# Altium schematic sheet UART_Bridge.SchDoc
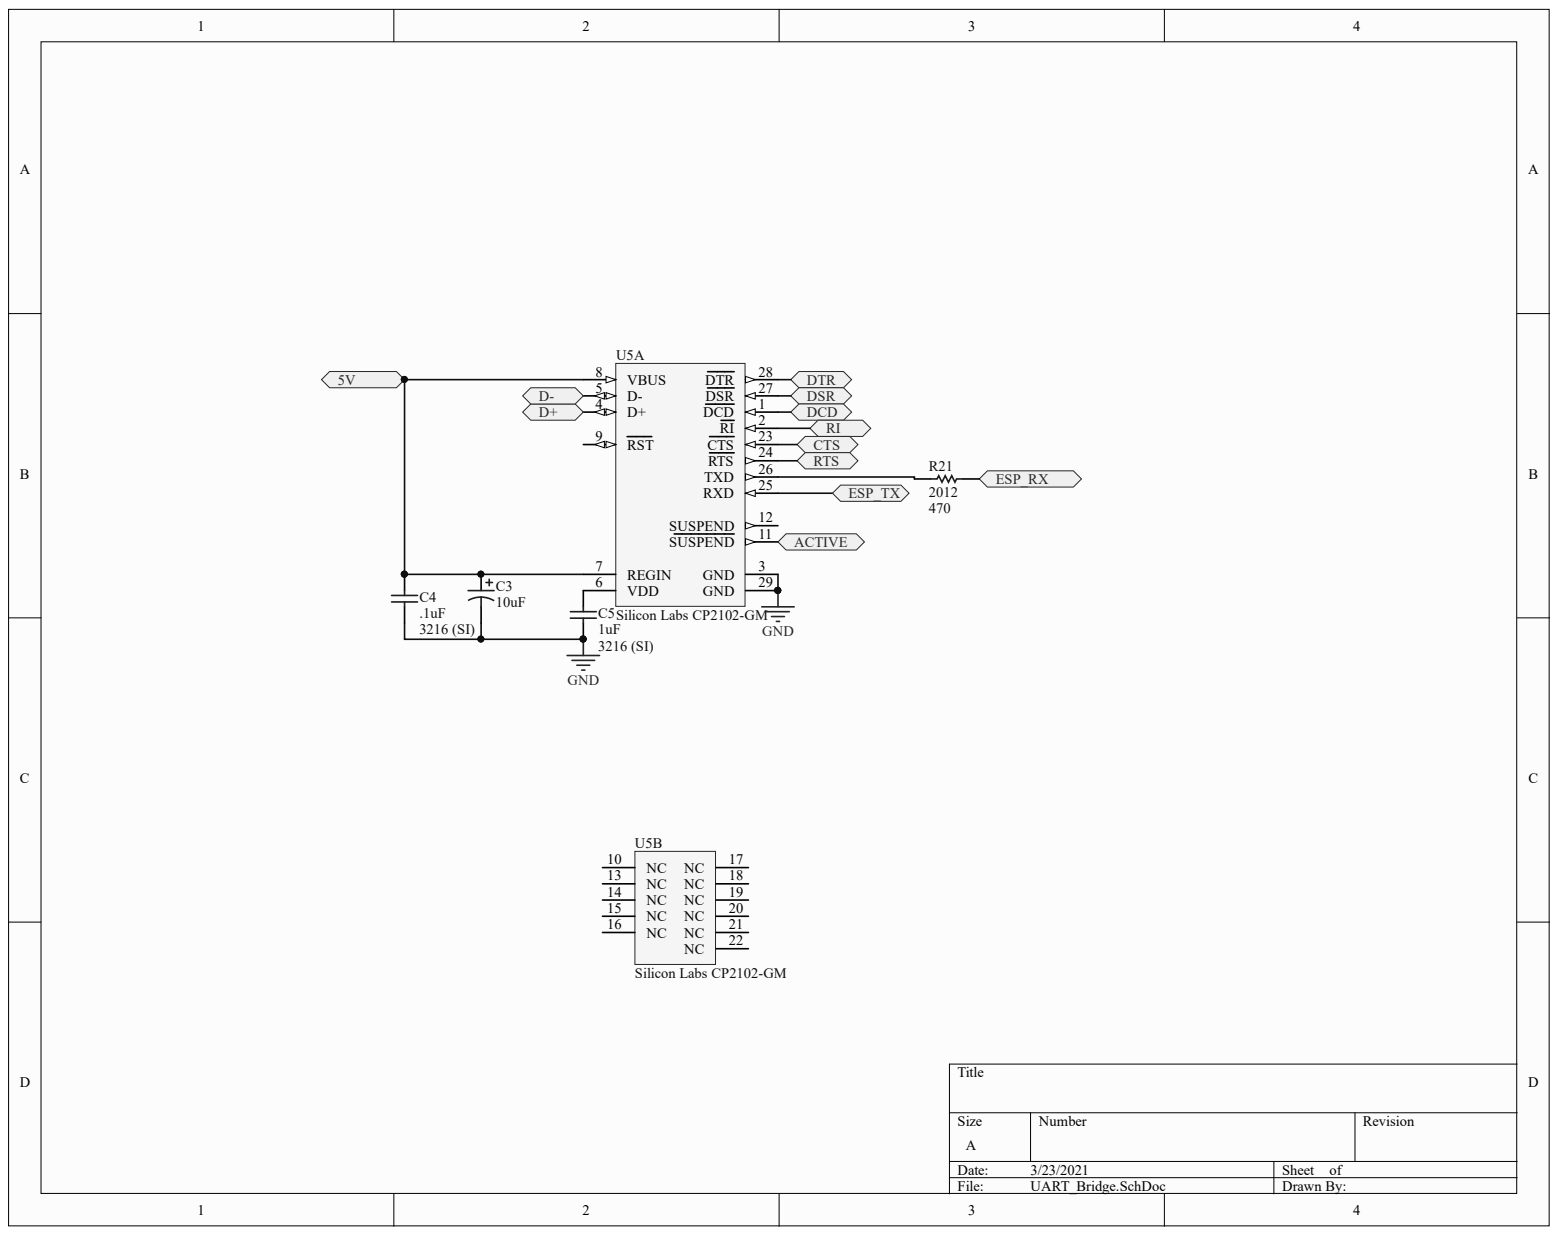
[diagram p0: UART_Bridge.SchDoc]

## Parts
C3  10uF  [PCB-imxzc0h3ulzopa7zwnih-1]
C4  .1uF  [CSMD1206GRID25PADB]
C5  1uF  [CSMD1206GRID25PADB]
R21  Case/Package (SI): 2012  [RESC2013X70X40ML20T20]  (hidden comment: Panasonic ERJ-6GEYJ822V)
U5  Silicon Labs CP2102-GM  Manufacturer Part Number: CP2102-GM  [PCB-r2q4wj0b96jlqp92htuq-1]  (parts A,B)

## Ports
5V, ACTIVE, CTS, D+, D-, DCD, DSR, DTR, ESP_RX, ESP_TX, RI, RTS

## Nets
GND: C3.2 C4.1 C5.1 U5.3(GND) U5.29(GND)
5V: C3.1 C4.2 U5.7(REGIN) U5.8(VBUS) ; port 5V
ACTIVE: U5.11(SUSPEND) ; port ACTIVE
CTS: U5.23(CTS) ; port CTS
D+: U5.4(D+) ; port D+
D-: U5.5(D-) ; port D-
DCD: U5.1(DCD) ; port DCD
DSR: U5.27(DSR) ; port DSR
DTR: U5.28(DTR) ; port DTR
ESP_RX: R21.1 ; port ESP_RX
ESP_TX: U5.25(RXD) ; port ESP_TX
RI: U5.2(RI) ; port RI
RTS: U5.24(RTS) ; port RTS
NetC5_2: C5.2 U5.6(VDD)
NetR21_2: R21.2 U5.26(TXD)

Not connected: U5 9(RST) 10(NC) 12(SUSPEND) 13(NC) 14(NC) 15(NC) 16(NC) 17(NC) 18(NC) 19(NC) 20(NC) 21(NC) 22(NC)

## Library data of the parts
C3 = CMP-6a29a3234e15b5ee-2: Capacitor Tantalum Solid 10uF 25V 10% (5.5 X 9mm) Radial 2.5mm 2.5 Ohm 125C Bulk; Manufacturer Part Number: TAP106K025SCS; Capacitance: 10.0 µF; Equivalent Series Resistance (ESR): 2.50 Ω; Lead-Free Status: Lead Free; Capacitance Tolerance: ±10%; Component Kind: Standard; Library Name: CommunityVault; Mounting Style: Through Hole; Number of Pins: 2; OctopartID: 6a29a3234e15b5ee; Octopart URL: http://octopart.com/tap106k025scs-avx-346; REACH SVHC Compliance: No SVHC; RoHS: Compliant; Size-Diameter: 5.59 mm; Size-Height: 8.89 mm
C4 C5 = CMP-150561-3: Cap Ceramic 1uF 25V X7R 20% SMD 1206 125C Plastic T/R; Lead-Free Status: R; Voltage Rating (DC): 25.0 V; Capacitance Tolerance: ±20%; Component Kind: Standard; Library Name: CommunityVault; Mounting Style: Surface Mount; OctopartID: f0ac39d8598d2f6b; Octopart Url: https://octopart.com/c1206c105m3ractu-kemet-8293447; Package/Case: 3216 (SI); Packaging: Cut Tape (CT); RoHS: Compliant
R21 = CMP-3687578-2: Thick Film Resistors - SMD 0805 8.2Kohms 5% Tol; Lead-Free Status: Lead Free; Power Rating: 0.125 W; Resistance: 470; Voltage Rating (DC): 150.0 V; Case/Package: 0805; Component Kind: Standard; Library Name: CommunityVault; Lifecycle Status: Not Listed by Manufacturer; Manufacturer Part Number: ERJ-6GEYJ822V; Mounting Style: Surface Mount; OctopartID: 0556cf11906ada91; Octopart Url: https://octopart.com/erj-6geyj822v-panasonic-55423066; Packaging: Cut Tape (CT); Resistance Tolerance: ±5%; RoHS: Compliant; Size-Height: 0.0006 m; Size-Length: 0.002 m; Size-Width: 0.00125 m
U5 = CMP-967561-7: Microcontroller Solutions - Interface Products USB to UART Bdg Chip; Data Rate: 1000000.0 bps; Lead-Free Status: Lead Free; Supply Current: 0.1 A; Supply Voltage (DC): V; Case/Package: MLP; Component Kind: Standard; Library Name: CommunityVault; Mounting Style: Surface Mount; Number of Pins: 28; OctopartID: 84694d644fd15bae; Octopart URL: http://octopart.com/cp2102-gm-silicon+labs-519902; Packaging: Bulk; RoHS: Compliant
